# Altium schematic sheet 3-CAN.SchDoc(3-CAN)
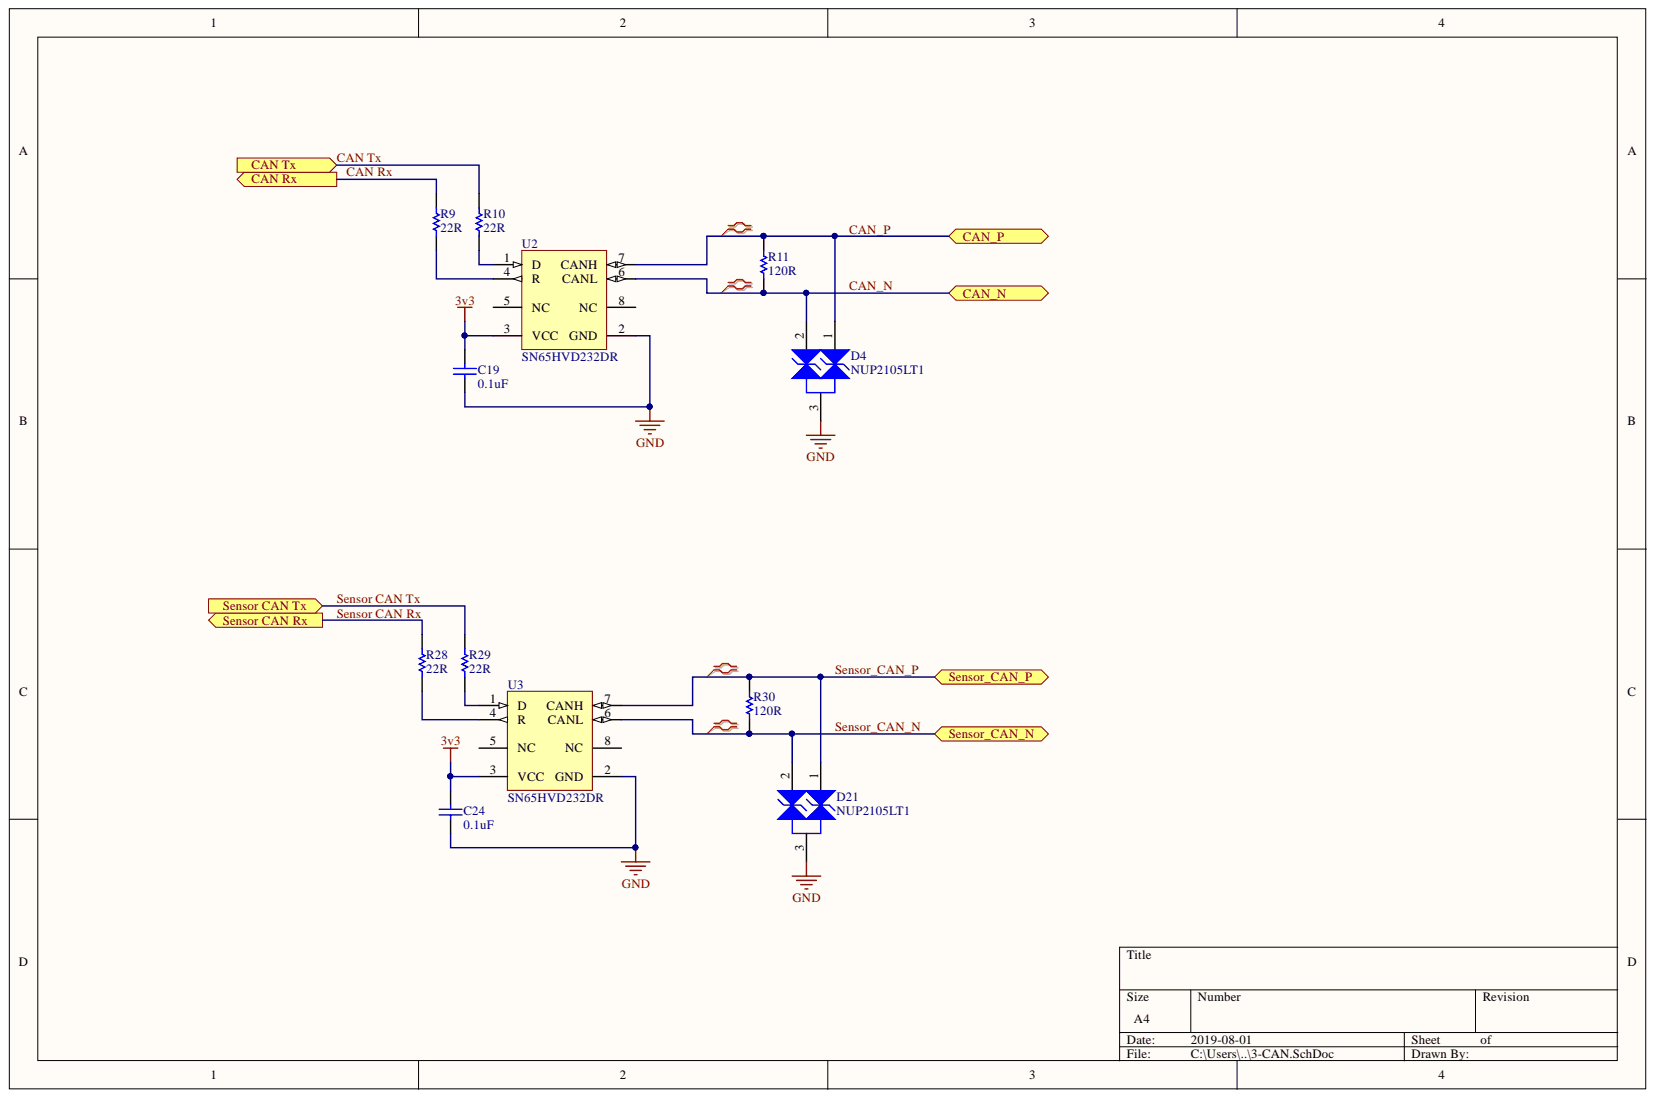
[diagram p0: 3-CAN.SchDoc]

## Parts
C19  0.1uF  [CAPC1608X90X30NL10T20]
C24  0.1uF  [CAPC1608X90X30NL10T20]
D4  NUP2105LT1  [ONSC-SOT-23-3-318-08_V]
D21  NUP2105LT1  [ONSC-SOT-23-3-318-08_V]
R9  22R  [RESC0603(1608)_N]
R10  22R  [RESC0603(1608)_N]
R11  120R  [RESC0603(1608)_N]
R28  22R  [RESC0603(1608)_N]
R29  22R  [RESC0603(1608)_N]
R30  120R  [RESC0603(1608)_N]
U2  SN65HVD232DR  [SN65HVD232]
U3  SN65HVD232DR  [SN65HVD232]

## Ports
CAN Rx, CAN Tx, CAN_N, CAN_P, Sensor CAN Rx, Sensor CAN Tx, Sensor_CAN_N, Sensor_CAN_P

## Nets
3v3: C19.2 C24.2 U2.3(VCC) U3.3(VCC)
GND: C19.1 C24.1 D4.3 D21.3 U2.2(GND) U3.2(GND)
CAN Rx: R9.2 ; port CAN Rx
CAN Tx: R10.2 ; port CAN Tx
CAN_N: D4.2 R11.1 U2.6(CANL) ; port CAN_N
CAN_P: D4.1 R11.2 U2.7(CANH) ; port CAN_P
Sensor CAN Rx: R28.2 ; port Sensor CAN Rx
Sensor CAN Tx: R29.2 ; port Sensor CAN Tx
Sensor_CAN_N: D21.2 R30.1 U3.6(CANL) ; port Sensor_CAN_N
Sensor_CAN_P: D21.1 R30.2 U3.7(CANH) ; port Sensor_CAN_P
NetR9_1: R9.1 U2.4(R)
NetR10_1: R10.1 U2.1(D)
NetR28_1: R28.1 U3.4(R)
NetR29_1: R29.1 U3.1(D)

Not connected: U2 5(NC) 8(NC); U3 5(NC) 8(NC)

## Library data of the parts
C19 C24 = CMP-2000-06092-1: Chip Capacitor, 100 nF, +/- 10%, 50 V, -55 to 125 degC, 0603 (1608 Metric), RoHS, Tape and Reel; Manufacturer: SamsungElectro-Mechanics; Voltage: 50Vdc; Rad Hard: No; Product Length: 1.6mm; Product Height: 0.8mm; Dielectric: X7R; Tolerance: 10%; Product Depth: 0.8mm; Packaging: Tape&Reel; Package Reference: 0603; Package Description: 2-Pin Surface Mount Device, Body 1.60 x 0.80 mm; Package/Case: 0603; Operating Temperature: -55to125°C; Mounting Technology: SurfaceMount; Mounting: SurfaceMount; Manufacturer URL: http://www.samsungsem.com/global/index.jsp; Library Name: Altium Content Vault; Datasheet Version: 06/2016; Datasheet URL: http://www.samsungsem.com/kr/support/product-search/mlcc/__icsFiles/afieldfile/2016/08/18/S_CL10B104KB8SFNC.pdf; Construction: Flat; Component Kind: Standard; Category: CapacitorCeramicMultilayer; Capacitance Value: 0.1uF
D4 D21 = CMP-1063-00165-1: Dual Line CAN Bus Protector, 3-Pin SOT-23, Tape and Reel; Manufacturer: On Semiconductor; V(BR) Min (V): 26.2; PPK Max (W): 350; Number of Lines: 2; IR Max (uA): 0.1; Direction: Bidirectional; C Max (pF): 30; PartNumber: NUP2105LT1; Packing: Tape and Reel; PackageVersion: Rev. AP, 11/2009; PackageReference: SOT-23-3-318-08; PackageDescription: 3-Pin Small Outline Transistor, Body 2.92 x 1.3 mm, Pitch 0.95 mm; Mounting Technology: Surface Mount; Library Name: Altium Content Vault; DatasheetVersion: Rev. 5; ComponentLink3URL: http://www.onsemi.cn/pub_link/Collateral/318-08.PDF; ComponentLink3Description: Package Specification; ComponentLink2URL: http://www.onsemi.cn/pub_link/Collateral/NUP2105L-D.PDF; ComponentLink2Description: Datasheet; ComponentLink1URL: http://www.onsemi.com/; ComponentLink1Description: Manufacturer URL; Component Kind: Standard
R9 R10 R28 R29 = CMP-1012-00034-1: 22R 0.1W 5% 0603 (1608 Metric)  SMD; Value: 22.00 Ohm; Case-Metric: 1608; Case-EIA: 0603; Tolerance: 5 %; Technology: SMT; Power: 0.1 W; Library Name: Altium Content Vault; Component Kind: Standard
R11 R30 = CMP-1012-00052-1: 120R 0.1W 5% 0603 (1608 Metric)  SMD; Value: 120.00 Ohm; Case-Metric: 1608; Case-EIA: 0603; Tolerance: 5 %; Technology: SMT; Power: 0.1 W; Library Name: Altium Content Vault; Component Kind: Standard
U2 U3 = CMP-0315-00075-2: 3.3 V Can Transceiver, 17 mA, -40 to 85 degC, 8-pin SOIC (D), Green (RoHS & no Sb/Br); Manufacturer: Texas Instruments; Transient Pulse Protection(V): -25 to 25; Supply Voltage(s)(V): 3.3; Receivers Per Package: 1; Rating: Catalog; ICC(Max)(mA): 17; SubFamily: CAN; PartNumber: SN65HVD232DR; PackageVersion: revM, Jun-2011; PackageReference: D0008A; PackageDescription: 8-Pin Plastic Small Outline Integrated Circuit, 4.9 x 3.9 mm, Pitch 1.27 mm Pitch; Mounting Technology: Surface Mount; Library Name: Altium Content Vault; Isolated: No; Eco-Plan: Green (RoHS & no Sb/Br); Drivers Per Package: 1; DatasheetVersion: SLOS346K; ComponentLink1URL: http://www.ti.com/general/docs/lit/getliterature.tsp?genericPartNumber=SN65HVD232&fileType=pdf; ComponentLink1Description: Datasheet; Component Kind: Standard; Code_JEDEC: MS-012AA
